# Altium schematic sheet Main.SchDoc(Main)
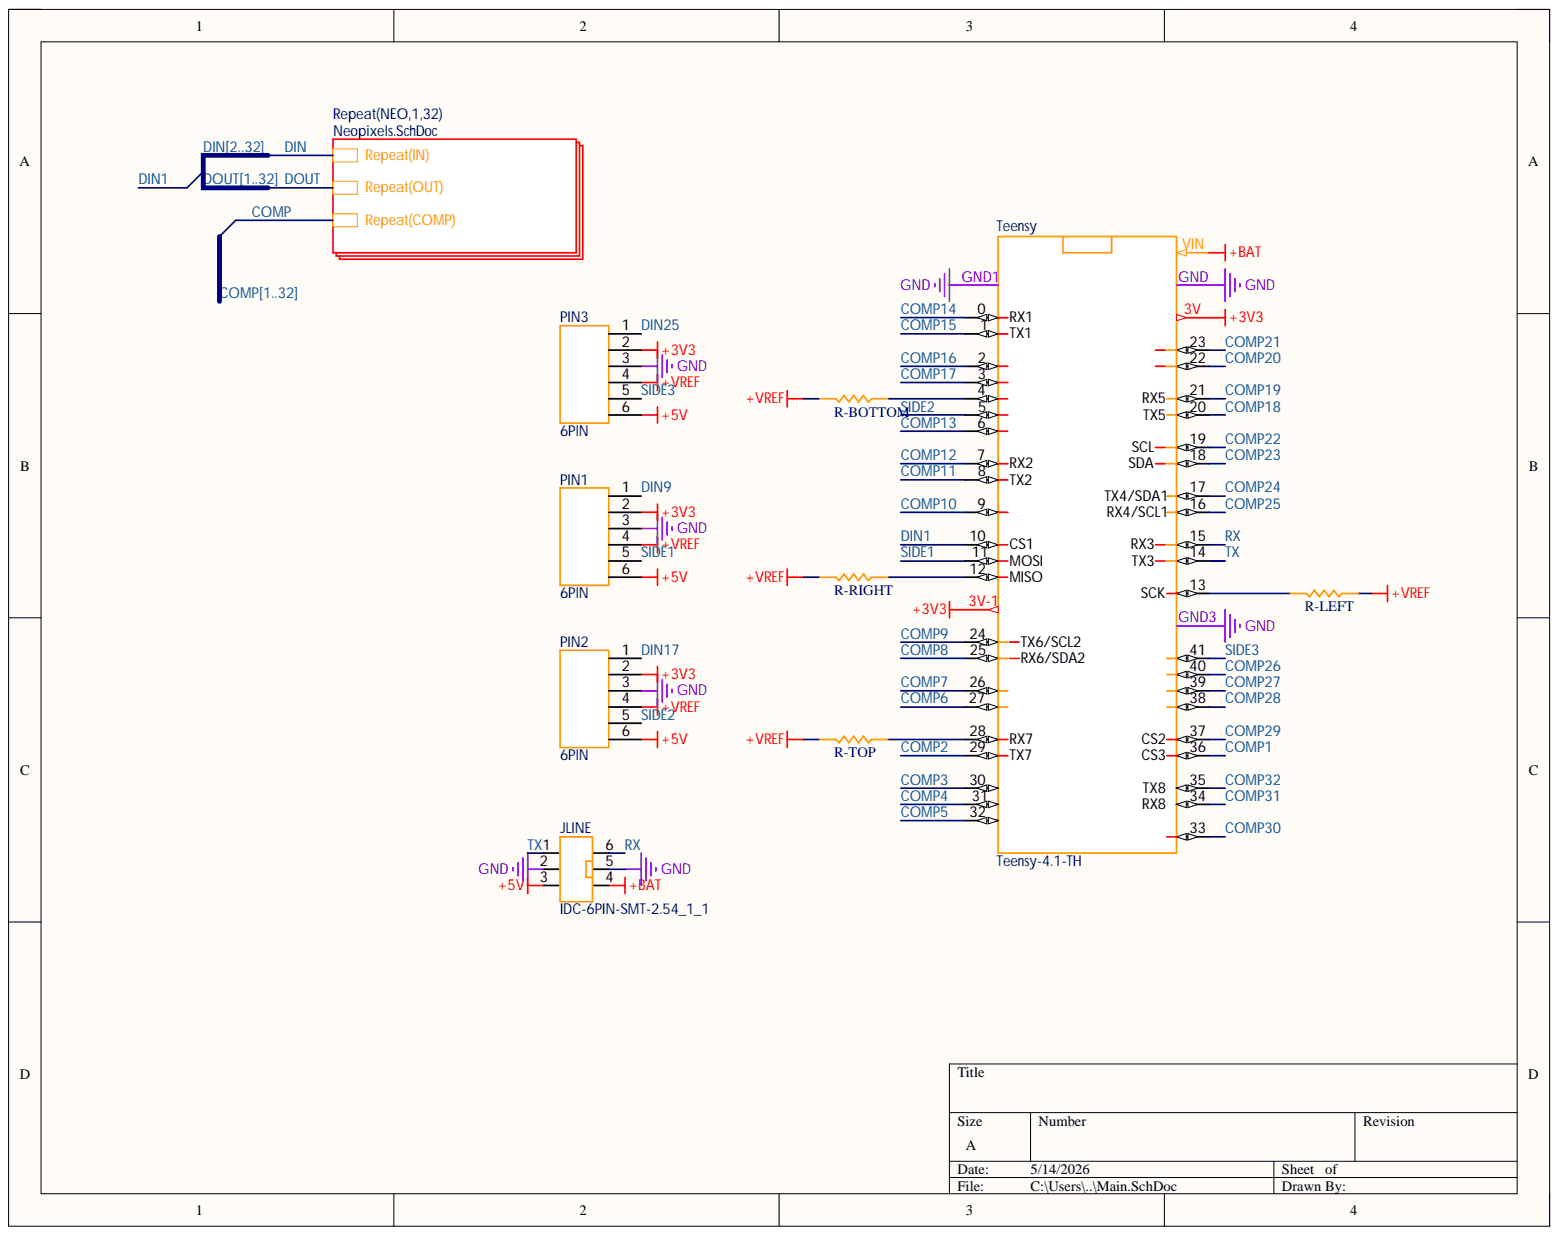
[diagram p0: Main.SchDoc]

## Parts
JLINE  IDC-6PIN-SMT-2.54_1_1  [IDC-6PIN-SMT-2.54]
PIN1  6PIN  [6PIN]
PIN2  6PIN  [6PIN]
PIN3  6PIN  [6PIN]
R-BOTTOM  RES_0603  [RES_0603]  (value not drawn)
R-LEFT  RES_0603  [RES_0603]  (value not drawn)
R-RIGHT  RES_0603  [RES_0603]  (value not drawn)
R-TOP  RES_0603  [RES_0603]  (value not drawn)
Teensy  Teensy-4.1-TH  [Teensy-4.1-TH]

## Nets
+3V3: PIN1.2 PIN2.2 PIN3.2 Teensy.3V Teensy.3V-1
+5V: JLINE.3 PIN1.6 PIN2.6 PIN3.6
+BAT: JLINE.4 Teensy.VIN
+VREF: PIN1.4 PIN2.4 PIN3.4 R-BOTTOM.1 R-LEFT.2 R-RIGHT.1 R-TOP.1
GND: JLINE.2 JLINE.5 PIN1.3 PIN2.3 PIN3.3 Teensy.GND Teensy.GND1 Teensy.GND3
COMP1: Teensy.36(CS3)  [also labelled COMP]
COMP2: Teensy.29(TX7)  [also labelled COMP]
COMP3: Teensy.30  [also labelled COMP]
COMP4: Teensy.31  [also labelled COMP]
COMP5: Teensy.32  [also labelled COMP]
COMP6: Teensy.27  [also labelled COMP]
COMP7: Teensy.26  [also labelled COMP]
COMP8: Teensy.25(RX6/SDA2)  [also labelled COMP]
COMP9: Teensy.24(TX6/SCL2)  [also labelled COMP]
COMP10: Teensy.9  [also labelled COMP]
COMP11: Teensy.8(TX2)  [also labelled COMP]
COMP12: Teensy.7(RX2)  [also labelled COMP]
COMP13: Teensy.6  [also labelled COMP]
COMP14: Teensy.0(RX1)  [also labelled COMP]
COMP15: Teensy.1(TX1)  [also labelled COMP]
COMP16: Teensy.2  [also labelled COMP]
COMP17: Teensy.3  [also labelled COMP]
COMP18: Teensy.20(TX5)  [also labelled COMP]
COMP19: Teensy.21(RX5)  [also labelled COMP]
COMP20: Teensy.22  [also labelled COMP]
COMP21: Teensy.23  [also labelled COMP]
COMP22: Teensy.19(SCL)  [also labelled COMP]
COMP23: Teensy.18(SDA)  [also labelled COMP]
COMP24: Teensy.17(TX4/SDA1)  [also labelled COMP]
COMP25: Teensy.16(RX4/SCL1)  [also labelled COMP]
COMP26: Teensy.40  [also labelled COMP]
COMP27: Teensy.39  [also labelled COMP]
COMP28: Teensy.38  [also labelled COMP]
COMP29: Teensy.37(CS2)  [also labelled COMP]
COMP30: Teensy.33  [also labelled COMP]
COMP31: Teensy.34(RX8)  [also labelled COMP]
COMP32: Teensy.35(TX8)  [also labelled COMP]
DIN1: Teensy.10(CS1)
DIN9: PIN1.1  [also labelled DIN]
DIN17: PIN2.1  [also labelled DIN]
DIN25: PIN3.1  [also labelled DIN]
RX: JLINE.6 Teensy.15(RX3)
SIDE1: PIN1.5 Teensy.11(MOSI)
SIDE2: PIN2.5 Teensy.5
SIDE3: PIN3.5 Teensy.41
TX: JLINE.1 Teensy.14(TX3)
NetR-BOTTOM_2: R-BOTTOM.2 Teensy.4
NetR-LEFT_1: R-LEFT.1 Teensy.13(SCK)
NetR-RIGHT_2: R-RIGHT.2 Teensy.12(MISO)
NetR-TOP_2: R-TOP.2 Teensy.28(RX7)
DIN2:  [also labelled DIN]
DIN3:  [also labelled DIN]
DIN4:  [also labelled DIN]
DIN5:  [also labelled DIN]
DIN6:  [also labelled DIN]
DIN7:  [also labelled DIN]
DIN8:  [also labelled DIN]
DIN10:  [also labelled DIN]
DIN11:  [also labelled DIN]
DIN12:  [also labelled DIN]
DIN13:  [also labelled DIN]
DIN14:  [also labelled DIN]
DIN15:  [also labelled DIN]
DIN16:  [also labelled DIN]
DIN18:  [also labelled DIN]
DIN19:  [also labelled DIN]
DIN20:  [also labelled DIN]
DIN21:  [also labelled DIN]
DIN22:  [also labelled DIN]
DIN23:  [also labelled DIN]
DIN24:  [also labelled DIN]
DIN26:  [also labelled DIN]
DIN27:  [also labelled DIN]
DIN28:  [also labelled DIN]
DIN29:  [also labelled DIN]
DIN30:  [also labelled DIN]
DIN31:  [also labelled DIN]
DIN32:  [also labelled DIN]
DOUT32:

## Library data of the parts
R-BOTTOM R-LEFT R-RIGHT R-TOP = CMP-009-00178-1: Resistor SMD 0603 generic; Component Kind: Standard; Component Type: Standard; Design Item ID: RES_0603; Pins: 2; Source: GenericRC.SchLib; Library Name: ChaBots Phoenix
JLINE = IDC-6PIN-SMT-2.54_1_1: Component Kind: Standard; Library Name: RC.IntLib
PIN1-PIN3 = 6PIN: Component Kind: Standard; Library Name: RC.IntLib
Teensy = Teensy-4.1-TH: Component Kind: Standard; Library Name: RC.IntLib
